# Altium schematic sheet OpenThereminUNO.SchDoc
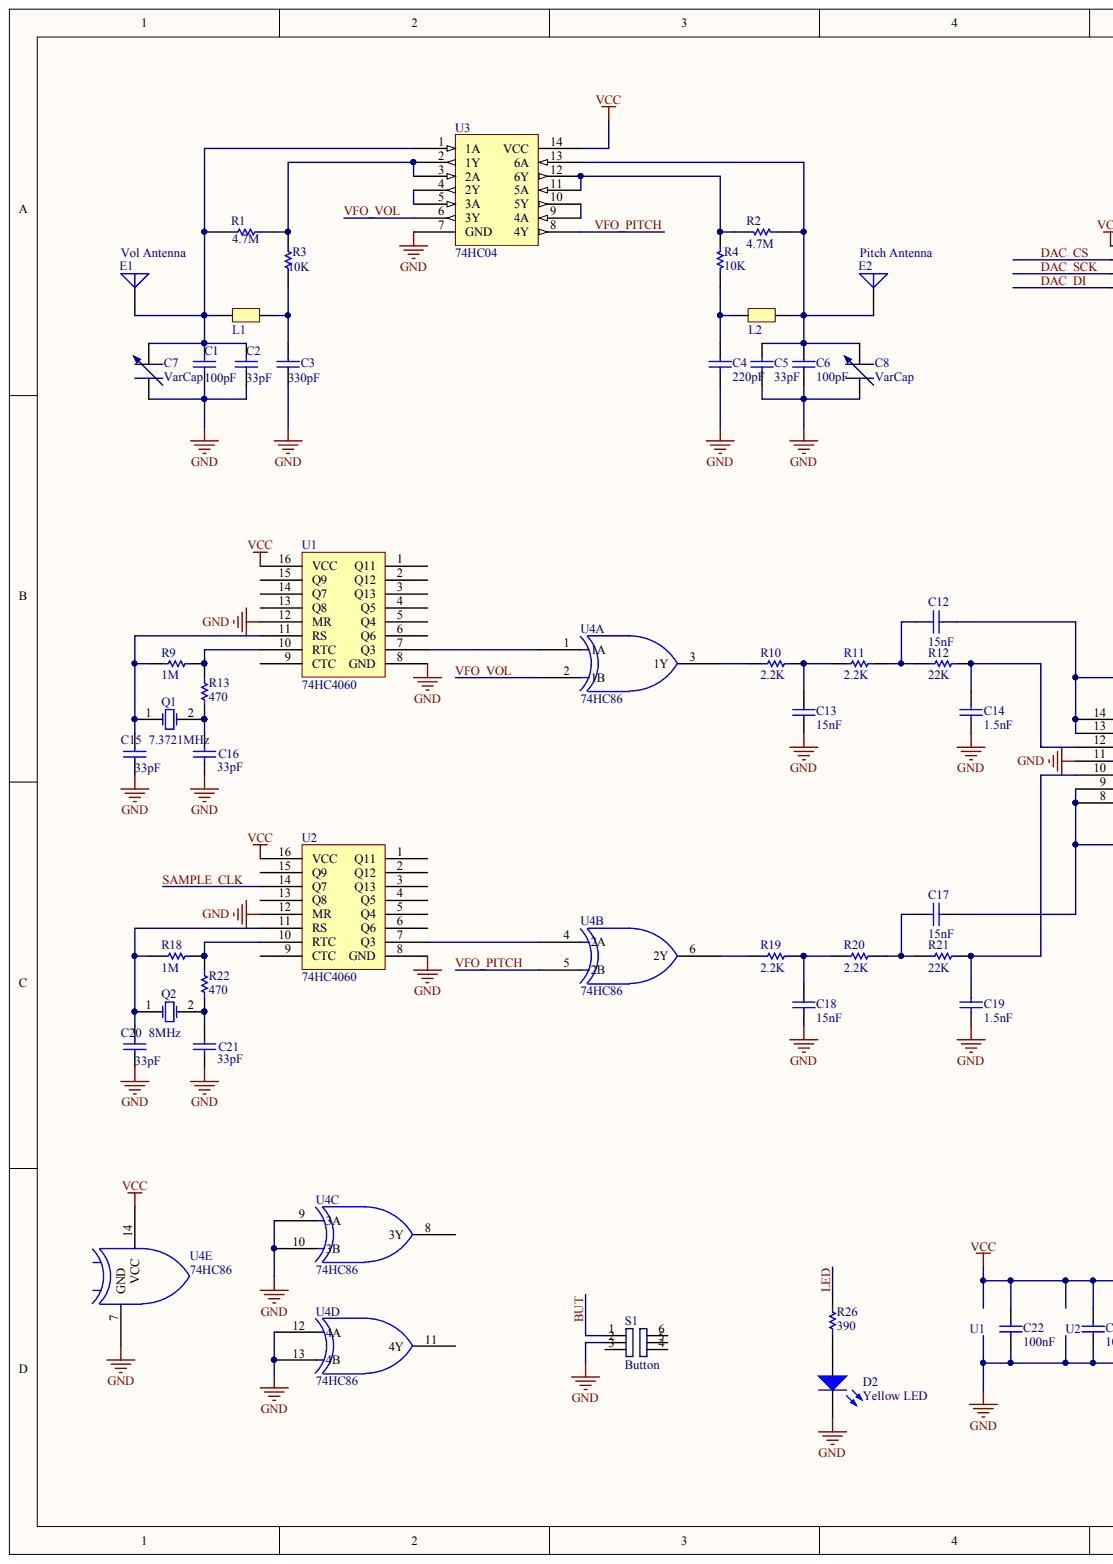
[diagram p0: OpenThereminUNO.SchDoc, left]
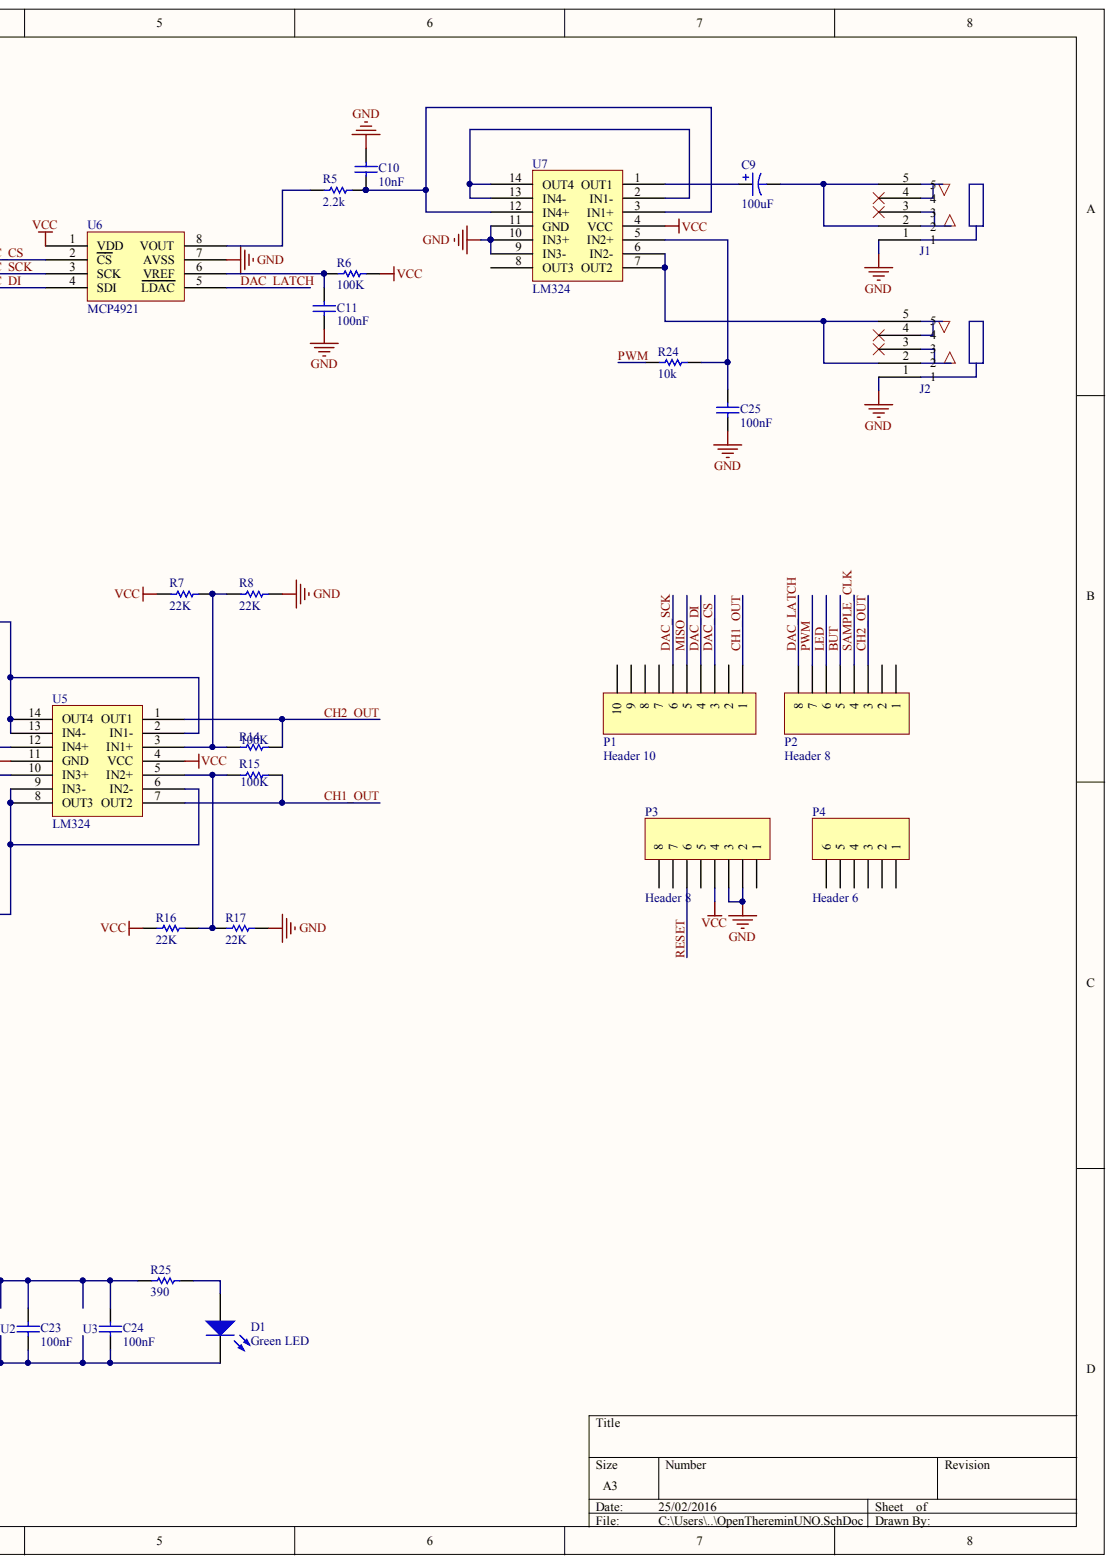
[diagram p1: OpenThereminUNO.SchDoc, right]

## Parts
C1  value 100pF  [CAP_100M]  (hidden comment: Cap)
C2  value 33pF  [CAP_100M]  (hidden comment: Cap)
C3  value 330pF  [CAP_100M]  (hidden comment: Cap)
C4  value 220pF  [CAP_100M]  (hidden comment: Cap)
C5  value 33pF  [CAP_100M]  (hidden comment: Cap)
C6  value 100pF  [CAP_100M]  (hidden comment: Cap)
C7  VarCap  [VarCapacitor]
C8  VarCap  [VarCapacitor]
C9  value 100uF  [CAP_200M]  (hidden comment: Cap Pol1)
C10  value 10nF  [CAP_100M]  (hidden comment: Cap)
C11  value 100nF  [CAP_100M]  (hidden comment: Cap)
C12  value 15nF  [CAP_100M]  (hidden comment: Cap)
C13  value 15nF  [CAP_100M]  (hidden comment: Cap)
C14  value 1.5nF  [CAP_100M]  (hidden comment: Cap)
C15  value 33pF  [CAP_100M]  (hidden comment: Cap)
C16  value 33pF  [CAP_100M]  (hidden comment: Cap)
C17  value 15nF  [CAP_100M]  (hidden comment: Cap)
C18  value 15nF  [CAP_100M]  (hidden comment: Cap)
C19  value 1.5nF  [CAP_100M]  (hidden comment: Cap)
C20  value 33pF  [CAP_100M]  (hidden comment: Cap)
C21  value 33pF  [CAP_100M]  (hidden comment: Cap)
C22  value 100nF  [CAP_100M]  (hidden comment: Cap)
C23  value 100nF  [CAP_100M]  (hidden comment: Cap)
C24  value 100nF  [CAP_100M]  (hidden comment: Cap)
C25  value 100nF  [CAP_100M]  (hidden comment: Cap)
D1  Green LED  [LED-1]
D2  Yellow LED  [LED-1]
E1  Vol Antenna  [ANTENNA]
E2  Pitch Antenna  [ANTENNA]
J1  Jack5  [JACK_5]  (value not drawn)
J2  Jack5  [JACK_5]  (value not drawn)
L1  Inductor  [INDUCT]  (value not drawn)
L2  Inductor  [INDUCT]  (value not drawn)
P1  Header 10  [HDR1X10]
P2  Header 8  [HDR1X8]
P3  Header 8  [HDR1X8]
P4  Header 6  [HDR1X6]
Q1  7.3721MHz  [R38]
Q2  8MHz  [R38]
R1  value 4.7M  [AXIAL-0.3]  (hidden comment: Res1)
R2  value 4.7M  [AXIAL-0.3]  (hidden comment: Res1)
R3  value 10K  [AXIAL-0.3]  (hidden comment: Res1)
R4  value 10K  [AXIAL-0.3]  (hidden comment: Res1)
R5  value 2.2k  [AXIAL-0.3]  (hidden comment: Res1)
R6  value 100K  [AXIAL-0.3]  (hidden comment: Res1)
R7  value 22K  [AXIAL-0.3]  (hidden comment: Res1)
R8  value 22K  [AXIAL-0.3]  (hidden comment: Res1)
R9  value 1M  [AXIAL-0.3]  (hidden comment: Res1)
R10  value 2.2K  [AXIAL-0.3]  (hidden comment: Res1)
R11  value 2.2K  [AXIAL-0.3]  (hidden comment: Res1)
R12  value 22K  [AXIAL-0.3]  (hidden comment: Res1)
R13  value 470  [AXIAL-0.3]  (hidden comment: Res1)
R14  value 100K  [AXIAL-0.3]  (hidden comment: Res1)
R15  value 100K  [AXIAL-0.3]  (hidden comment: Res1)
R16  value 22K  [AXIAL-0.3]  (hidden comment: Res1)
R17  value 22K  [AXIAL-0.3]  (hidden comment: Res1)
R18  value 1M  [AXIAL-0.3]  (hidden comment: Res1)
R19  value 2.2K  [AXIAL-0.3]  (hidden comment: Res1)
R20  value 2.2K  [AXIAL-0.3]  (hidden comment: Res1)
R21  value 22K  [AXIAL-0.3]  (hidden comment: Res1)
R22  value 470  [AXIAL-0.3]  (hidden comment: Res1)
R24  value 10k  [AXIAL-0.3]  (hidden comment: Res1)
R25  value 390  [AXIAL-0.3]  (hidden comment: Res1)
R26  value 390  [AXIAL-0.3]  (hidden comment: Res1)
S1  Button  [BUTTON_DIP6]
U1  74HC4060  [74HC4060_DIP16]
U2  74HC4060  [74HC4060_DIP16]
U3  74HC04  [74HC04_DIP14]
U4  74HC86  [74HC86_DIP14]  (parts A,B,C,D,E)
U5  LM324  [LM324_DIP14]
U6  MCP4921  [MCP4921_DIP8]
U7  LM324  [LM324_DIP14]

## Nets
GND: C1.1 C2.1 C3.1 C4.1 C5.1 C6.1 C7.1 C8.1 C10.2 C11.1 C13.1 C14.1 C15.1 C16.1 C18.1 C19.1 C20.1 C21.1 C22.1 C23.1 C24.1 C25.1 D1.2 D2.2 J1.1 J2.1 P3.2 P3.3 R8.1 R17.1 S1.2 U1.8(GND) U1.12(MR) U2.8(GND) U2.12(MR) U3.7(GND) U4.7(GND) U4.9(3A) U4.10(3B) U4.12(4A) U4.13(4B) U5.11(GND) U6.7(AVSS) U7.9(IN3-) U7.10(IN3+) U7.11(GND)
VCC: C22.2 C23.2 C24.2 P3.4 R6.1 R7.2 R16.2 R25.1 U1.16(VCC) U2.16(VCC) U3.14(VCC) U4.14(VCC) U5.4(VCC) U6.1(VDD) U7.4(VCC)
BUT: P2.5 S1.1
CH1_OUT: P1.1 R15.2 U5.7(OUT2)
CH2_OUT: P2.3 R14.2 U5.1(OUT1)
DAC_CS: P1.3 U6.2(CS)
DAC_DI: P1.4 U6.4(SDI)
DAC_LATCH: P2.8 U6.5(LDAC)
DAC_SCK: P1.6 U6.3(SCK)
LED: P2.6 R26.2
MISO: P1.5
PWM: P2.7 R24.2
RESET: P3.6
SAMPLE_CLK: P2.4 U2.14(Q7)
VFO_PITCH: U3.8(4Y) U4.5(2B)
VFO_VOL: U3.6(3Y) U4.2(1B)
NetC1_2: C1.2 C2.2 C7.2 E1.1 L1.1 R1.1 U3.1(1A)
NetC3_2: C3.2 L1.2 R3.1
NetC4_2: C4.2 L2.1 R4.1
NetC5_2: C5.2 C6.2 C8.2 E2.1 L2.2 R2.1 U3.13(6A)
NetC9_1: C9.1 U7.1(OUT1)
NetC9_2: C9.2 J1.2 J1.5
NetC10_1: C10.1 R5.2 U7.3(IN1+) U7.12(IN4+)
NetC11_2: C11.2 R6.2 U6.6(VREF)
NetC12_1: C12.1 U5.2(IN1-) U5.13(IN4-) U5.14(OUT4)
NetC12_2: C12.2 R11.2 R12.1
NetC13_2: C13.2 R10.2 R11.1
NetC14_2: C14.2 R12.2 U5.12(IN4+)
NetC15_2: C15.2 Q1.1 R9.2 U1.11(RS)
NetC16_2: C16.2 Q1.2 R13.1
NetC17_1: C17.1 U5.6(IN2-) U5.8(OUT3) U5.9(IN3-)
NetC17_2: C17.2 R20.2 R21.1
NetC18_2: C18.2 R19.2 R20.1
NetC19_2: C19.2 R21.2 U5.10(IN3+)
NetC20_2: C20.2 Q2.1 R18.2 U2.11(RS)
NetC21_2: C21.2 Q2.2 R22.1
NetC25_2: C25.2 R24.1 U7.5(IN2+)
NetD1_1: D1.1 R25.2
NetD2_1: D2.1 R26.1
NetJ2_2: J2.2 J2.5 U7.6(IN2-) U7.7(OUT2)
NetR1_2: R1.2 R3.2 U3.2(1Y) U3.3(2A)
NetR2_2: R2.2 R4.2 U3.11(5A) U3.12(6Y)
NetR5_1: R5.1 U6.8(VOUT)
NetR7_1: R7.1 R8.2 R14.1 U5.3(IN1+)
NetR9_1: R9.1 R13.2 U1.10(RTC)
NetR10_1: R10.1 U4.3(1Y)
NetR15_1: R15.1 R16.1 R17.2 U5.5(IN2+)
NetR18_1: R18.1 R22.2 U2.10(RTC)
NetR19_1: R19.1 U4.6(2Y)
NetU1_7: U1.7(Q3) U4.1(1A)
NetU2_7: U2.7(Q3) U4.4(2A)
NetU3_4: U3.4(2Y) U3.5(3A)
NetU3_9: U3.9(4A) U3.10(5Y)
NetU7_2: U7.2(IN1-) U7.13(IN4-) U7.14(OUT4)

Not connected: J1 3 4; J2 3 4; P1 2 7 8 9 10; P2 1 2; P3 1 5 7 8; P4 1 2 3 4 5 6; S1 3 4 5 6; U1 1(Q11) 2(Q12) 3(Q13) 4(Q5) 5(Q4) 6(Q6) 9(CTC) 13(Q8) 14(Q7) 15(Q9); U2 1(Q11) 2(Q12) 3(Q13) 4(Q5) 5(Q4) 6(Q6) 9(CTC) 13(Q8) 15(Q9); U4 8(3Y) 11(4Y); U7 8(OUT3)

## Library data of the parts
C1-C6 C10-C25 = Cap: Capacitor; Component Kind: Standard; LatestRevisionDate: 17-Jul-2002; LatestRevisionNote: Re-released for DXP Platform.; Library Name: Miscellaneous Devices.IntLib; PackageDescription: Radial Cap, Thru-Hole; 2 Leads; 0.3 in Pin Spacing; PackageReference: RAD-0.3; Published: 8-Jun-2000; Signal Integrity: Cap; Simulation: CAP; Publisher: Altium Limited
C7 C8 = VarCapacitor: Calibration Variable Capacitor; Component Kind: Standard; Library Name: ThereminLib.SchLib
C9 = Cap Pol1: Polarized Capacitor (Radial); Component Kind: Standard; LatestRevisionDate: 17-Jul-2002; LatestRevisionNote: Re-released for DXP Platform.; Library Name: Miscellaneous Devices.IntLib; PackageDescription: Polarized Capacitor (Radial); 2 Leads; PackageReference: RB7.6-15; Published: 8-Jun-2000; Signal Integrity: Cap Pol; Simulation: CAP; Publisher: Altium Limited
D1 D2 = LED1: Typical RED GaAs LED; Component Kind: Standard; LatestRevisionDate: 08-Jul-2005; LatestRevisionNote: Mech Layer 1 removed.; Library Name: Miscellaneous Devices.IntLib; PackageDescription: LED; 2 Leads; PackageReference: LED-1; Published: 8-Jun-2000; Simulation: LED1; Publisher: Altium Limited
E1 E2 = Antenna: Generic Antenna; Component Kind: Standard; LatestRevisionDate: 17-Jul-2002; LatestRevisionNote: Re-released for DXP Platform.; Library Name: Miscellaneous Devices.IntLib; PackageReference: PIN1; Published: 24-Mar-1999; Publisher: Altium Limited
J1 J2 = Jack5: 5 contacts 3.5mm Jack Plug; Component Kind: Standard; Library Name: ThereminLib.SchLib
P1 = Header 10: Header, 10-Pin; Component Kind: Standard; LatestRevisionDate: 17-Jul-2002; LatestRevisionNote: Re-released for DXP Platform.; Library Name: Miscellaneous Connectors.IntLib; Signal Integrity: Connector; Publisher: Altium Limited
P2 P3 = Header 8: Header, 8-Pin; Component Kind: Standard; LatestRevisionDate: 17-Jul-2002; LatestRevisionNote: Re-released for DXP Platform.; Library Name: Miscellaneous Connectors.IntLib; Signal Integrity: Connector; Publisher: Altium Limited
P4 = Header 6: Header, 6-Pin; Component Kind: Standard; LatestRevisionDate: 17-Jul-2002; LatestRevisionNote: Re-released for DXP Platform.; Library Name: Miscellaneous Connectors.IntLib; Signal Integrity: Connector; Publisher: Altium Limited
Q1 Q2 = XTAL: Crystal Oscillator; Component Kind: Standard; LatestRevisionDate: 17-Jul-2002; LatestRevisionNote: Re-released for DXP Platform.; Library Name: Miscellaneous Devices.IntLib; PackageDescription: Crystal, Thru-Hole; 2 Leads; Body 3.1 x 8.2 mm (Dia.xH); PackageReference: R38; Published: 8-Jun-2000; Simulation: XTAL; Publisher: Altium Limited
R1-R22 R24-R26 = Res1: Resistor; Component Kind: Standard; LatestRevisionDate: 17-Jul-2002; LatestRevisionNote: Re-released for DXP Platform.; Library Name: Miscellaneous Devices.IntLib; PackageDescription: Axial Device, Thru-Hole; 2 Leads; 0.3 in Pin Spacing; PackageReference: AXIAL-0.3; Published: 8-Jun-2000; Signal Integrity: Res; Simulation: RESISTOR; Publisher: Altium Limited
S1 = Button: A simple button; Component Kind: Standard; Library Name: ThereminLib.SchLib
U1 U2 = 74HC4060: 14-stage binary rippple counter with oscillator; Component Kind: Standard; Library Name: ThereminLib.SchLib
U3 = 74HC04: Hex Inverter; Component Kind: Standard; Library Name: ThereminLib.SchLib
U4 = 74HC86: Quad 2-input XOR Gate; Component Kind: Standard; Library Name: ThereminLib.SchLib
U5 U7 = LM324: Low-Power, Quad-Operational Amplifiers; Component Kind: Standard; Library Name: ThereminLib.SchLib
U6 = MCP4921: 12-Bit DAC with SPI Interface; Component Kind: Standard; Library Name: ThereminLib.SchLib
L1 L2 = Inductor: Component Kind: Standard; Description: Inductor; Library Name: ThereminLib.SchLib
